# Altium schematic sheet [03] - GPS-SL871-Module-V1.1.SchDoc
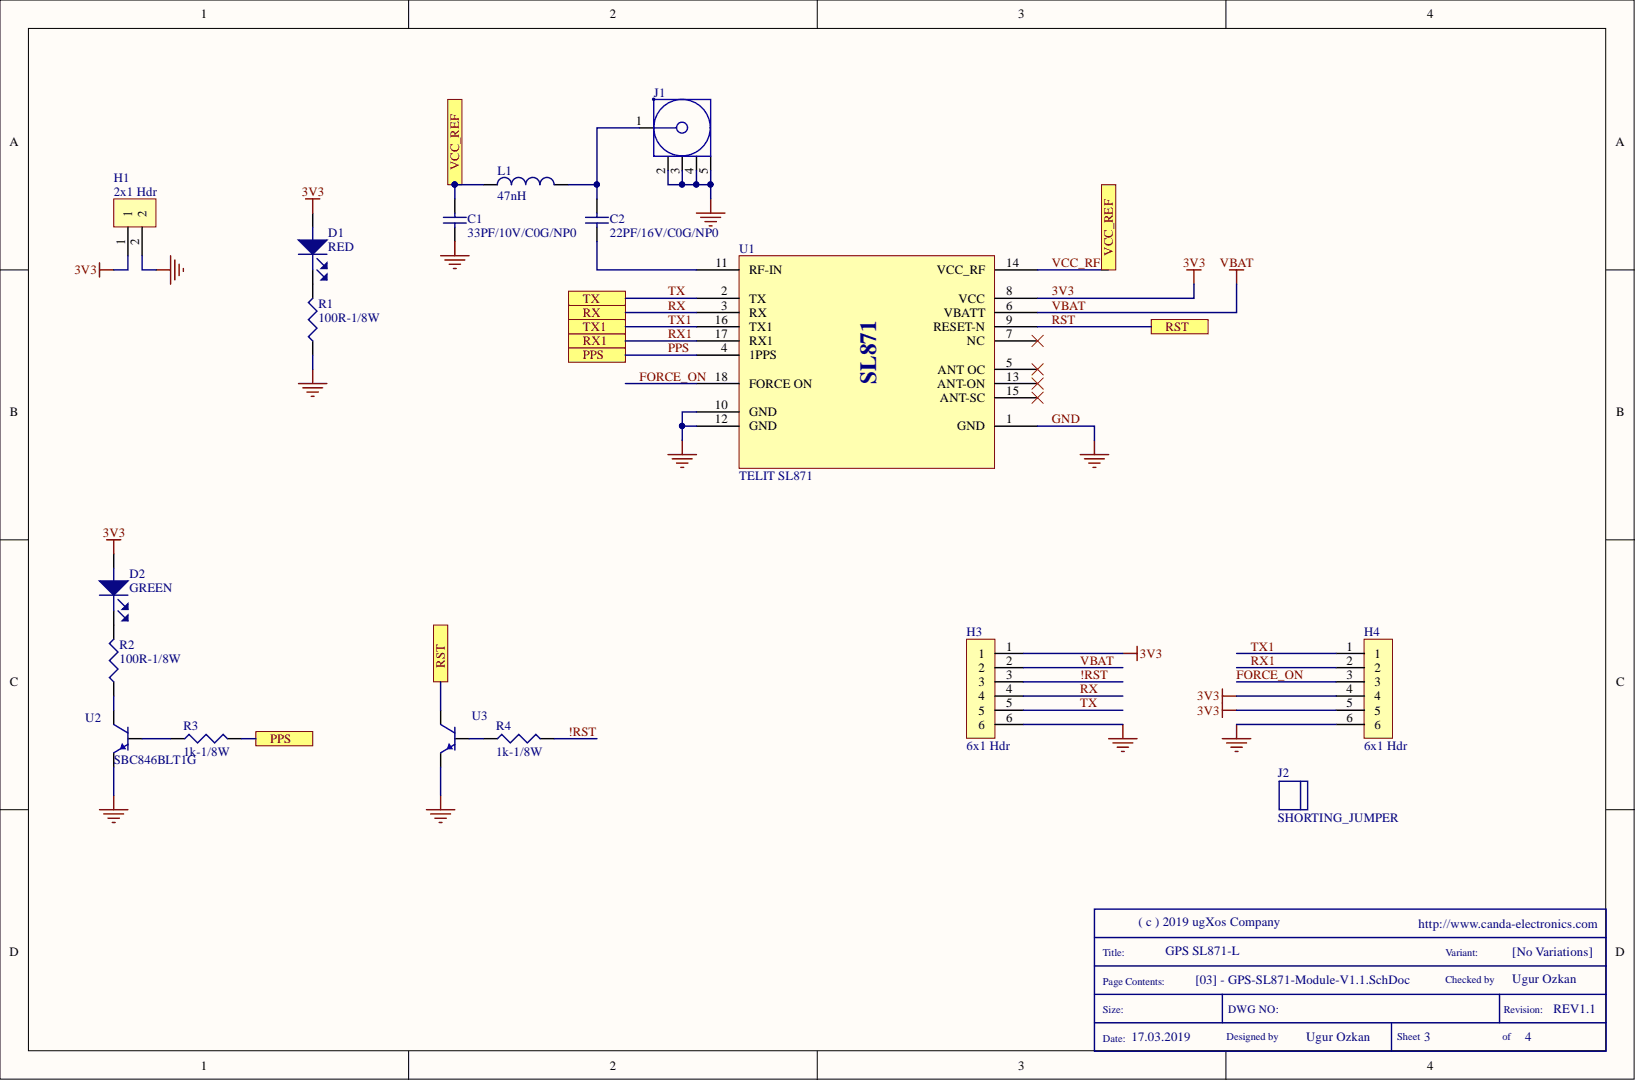
[diagram p0: [03] - GPS-SL871-Module-V1.1.SchDoc]

## Parts
C1  33PF/10V/C0G/NP0  [CAP0805]
C2  22PF/16V/C0G/NP0  [CAP0805]
D1  RED  [LED_0805]
D2  GREEN  [LED_0805]
H1  2x1 Hdr  [Hdr_Male_1x2_2.54mm]
H3  6x1 Hdr  [Hdr_Female_1x6_2.54mm]
H4  6x1 Hdr  [Hdr_Female_1x6_2.54mm]
J1  WR-SMA-THT  [WR_SMA_THT_60311002114501]  (value not drawn)
L1  47nH  [L0805]
R1  100R-1/8W  [R0805]
R2  100R-1/8W  [R0805]
R3  1k-1/8W  [R0805]
R4  1k-1/8W  [R0805]
U1  TELIT SL871  [Telit_SL871]
U2  SBC846BLT1G  [SOT-23]
U3  SBC846BLT1G  [SOT-23]

## Ports
PPS, RST, RX, RX1, TX, TX1, VCC_REF

## Nets
3V3: D1.1 D2.1 H1.1 H3.1 H4.4 H4.5 U1.8(VCC)
!RST: H3.3 R4.2
FORCE_ON: H4.3 U1.18(FORCE_ON)
GND: C1.2 H1.2 H3.6 H4.6 J1.2 J1.3 J1.4 J1.5 R1.1 U1.1(GND) U1.10(GND) U1.12(GND) U2.2 U3.2
PPS: R3.2 U1.4(1PPS) ; port PPS
RST: U1.9(RESET-N) U3.3 ; port RST
RX: H3.4 U1.3(RX) ; port RX
RX1: H4.2 U1.17(RX1) ; port RX1
TX: H3.5 U1.2(TX) ; port TX
TX1: H4.1 U1.16(TX1) ; port TX1
VBAT: H3.2 U1.6(VBATT)
VCC_RF: C1.1 L1.1 U1.14(VCC_RF) ; port VCC_REF
NetC2_1: C2.1 U1.11(RF-IN)
NetC2_2: C2.2 J1.1 L1.2
NetD1_2: D1.2 R1.2
NetD2_2: D2.2 R2.2
NetR2_1: R2.1 U2.3
NetR3_1: R3.1 U2.1
NetR4_1: R4.1 U3.1

Not connected: U1 5(ANT_OC) 7(NC) 13(ANT-ON) 15(ANT-SC)

## Library data of the parts
C1 = 885012007003: CAP CER 33PF 10V C0G/NP0 0805; Manufacturer: Wurth Electronics; Tolerance: 5%; Capacitance: 33pF; Case/Package: 0805; Case Code (Imperial): 0805; Case Code (Metric): 2012; Category: Capacitors; Component Kind: Standard; Length: 0.079inch; Manufacturer Part Number: 885012007003; Max Operating Temperature: 125°C; Min Operating Temperature: -55°C; Mount: Surface Mount; Packaging: Tape and Reel; Pricing 1: 1 = 0,10000, 10 = 0,04100, 100 = 0,03690, 500 = 0,03262 (USD); RoHS: Yes; Stock 1: 2029; Supplier 1: Digi-Key; Supplier Part Number 1: 732-7808-1-ND; Thickness: 0.028inch; Voltage Rating: 10V; Width: 0.049inch; Library Name: ugXos_Library.SchLib
C2 = 885012007012: CAP CER 22PF 16V C0G/NP0 0805; Manufacturer: Wurth Electronics; Tolerance: 5%; Capacitance: 22pF; Case/Package: 0805; Case Code (Imperial): 0805; Case Code (Metric): 2012; Category: Capacitors; Component Kind: Standard; Length: 0.079inch; Manufacturer Part Number: 885012007012; Max Operating Temperature: 125°C; Min Operating Temperature: -55°C; Mount: Surface Mount; Packaging: Tape and Reel; Pricing 1: 1 = 0,10000, 10 = 0,04300, 100 = 0,03830, 500 = 0,03390 (USD); RoHS: Yes; Stock 1: 22; Supplier 1: Digi-Key; Supplier Part Number 1: 732-7816-1-ND; Thickness: 0.028inch; Voltage Rating: 16V; Width: 0.049inch; Library Name: ugXos_Library.SchLib
D1 = 150080RS75000: LED, 0805, RED, 150MCD, 625NM; Manufacturer: Wurth Electronics; Forward Current: 30mA; Forward Voltage: 2V; Lens Style: Clear,Rectangular; Test Current: 20mA; Viewing Angle: 140°; Case/Package: 0805; Case Code (Imperial): 0805; Case Code (Metric): 2012; Category: Optoelectronics; Color: Red; Component Kind: Standard; ComponentLink1Description: http://www.farnell.com/datasheets/1911350.pdf?src-supplier=Element14; ComponentLink1URL: http://www.farnell.com/datasheets/1911350.pdf?src-supplier=Element14; ComponentLink2Description: http://www.produktinfo.conrad.com/datenblaetter/750000-774999/759435-da-01-en-CHIPLED_0805_W_KL_ROT_150080RS75000.pdf?src-supplier=Conrad; ComponentLink2URL: http://www.produktinfo.conrad.com/datenblaetter/750000-774999/759435-da-01-en-CHIPLED_0805_W_KL_ROT_150080RS75000.pdf?src-supplier=Conrad; Dominant Wavelength: 625nm; Height: 0.7mm; Length: 2mm; Luminous Intensity: 150mcd; Manufacturer Part Number: 150080RS75000; Max Operating Temperature: 85°C; Min Operating Temperature: -40°C; Mount: Surface Mount; Package Quantity: 4000; Packaging: Tape and Reel; Pricing 1: 1 = 0,17000, 50 = 0,16000, 100 = 0,13700, 500 = 0,11800 (USD); REACH SVHC: Unknown; RoHS: Yes; Stock 1: 58016; Supplier 1: Digi-Key; Supplier Part Number 1: 732-4984-1-ND; Wavelength: 625nm; Width: 1.25mm; Library Name: ugXos_Library.SchLib
D2 = 150080VS75000: LED, 0805, B GREEN, 40MCD, 570NM; Manufacturer: Wurth Electronics; Forward Current: 30mA; Forward Voltage: 2V; Lens Style: Clear,Rectangular; Test Current: 20mA; Viewing Angle: 140°; Case/Package: 0805; Case Code (Imperial): 0805; Case Code (Metric): 2012; Category: Optoelectronics; Color: Green; Component Kind: Standard; ComponentLink1Description: http://www.farnell.com/datasheets/1911352.pdf?src-supplier=Element14; ComponentLink1URL: http://www.farnell.com/datasheets/1911352.pdf?src-supplier=Element14; ComponentLink2Description: https://katalog.we-online.de/led/datasheet/150080VS75000.pdf?src-supplier=Digi-Key; ComponentLink2URL: https://katalog.we-online.de/led/datasheet/150080VS75000.pdf?src-supplier=Digi-Key; ComponentLink3Description: http://www.produktinfo.conrad.com/datenblaetter/750000-774999/759437-da-01-en-CHIPLED_0805_W_KL_H_GRUEN_150080VS7500.pdf?src-supplier=Conrad; ComponentLink3URL: http://www.produktinfo.conrad.com/datenblaetter/750000-774999/759437-da-01-en-CHIPLED_0805_W_KL_H_GRUEN_150080VS7500.pdf?src-supplier=Conrad; Dominant Wavelength: 570nm; Height: 0.7mm; Length: 2mm; Luminous Intensity: 40mcd; Manufacturer Part Number: 150080VS75000; Max Operating Temperature: 85°C; Min Operating Temperature: -40°C; Mount: Surface Mount; Package Quantity: 4000; Packaging: Tape and Reel; Pricing 1: 1 = 0,17000, 50 = 0,16000, 100 = 0,13700, 500 = 0,11800 (USD); REACH SVHC: Unknown; RoHS: Yes; Stock 1: 80308; Supplier 1: Digi-Key; Supplier Part Number 1: 732-4986-1-ND; Wavelength: 570nm; Width: 1.25mm; Library Name: ugXos_Library.SchLib
H1 = 61300211121: Connector, Vertical, Through Hole, Header, 2, 2.54 Mm; Manufacturer: Wurth Electronics; Connector Type: Male Pin; Current Rating: 3A; Gender: Male; Number of Contacts: 2; Pitch: 0.1inch; Voltage Rating: 250V; Category: Connectors; Color: Black; Component Kind: Standard; ComponentLink1Description: http://www.mouser.com/ds/2/445/6130xx11121-538006.pdf?src-supplier=Mouser; ComponentLink1URL: http://www.mouser.com/ds/2/445/6130xx11121-538006.pdf?src-supplier=Mouser; Contact Gender: Male; Contact Material: Copper,Copper Alloy; Contact Mating Length: 0.236inch; Contact Plating: Gold; Housing Material: PBT; Lead Free: Lead Free; Manufacturer Part Number: 61300211121; Max Operating Temperature: 125°C; Min Operating Temperature: -40°C; Mount: Through Hole; Number of Positions: 2; Number of Rows: 1; Packaging: Bulk; Pricing 1: 1 = 0,13000, 10 = 0,10600, 25 = 0,08800, 50 = 0,07400, 100 = 0,06700, 1000 = 0,06100 (USD); REACH SVHC: Unknown; RoHS: Yes; Stock 1: 132528; Supplier 1: Digi-Key; Supplier Part Number 1: 732-5315-ND; Termination: Through Hole; Library Name: ugXos_Library.SchLib
H3 H4 = 61300611121: Connector, Vertical, Through Hole, Header 6, 2.54 Mm; Manufacturer: Wurth Electronics; Connector Type: Male Pin; Current Rating: 3A; Gender: Male; Number of Contacts: 6; Pitch: 0.1inch; Voltage Rating: 250V; Category: Connectors; Color: Black; Component Kind: Standard; Contact Gender: Male; Contact Material: Copper,Copper Alloy; Contact Mating Length: 0.236inch; Contact Plating: Gold; Housing Material: PBT; Lead Free: Lead Free; Manufacturer Part Number: 61300611121; Max Operating Temperature: 125°C; Min Operating Temperature: -40°C; Mount: Through Hole; Number of Positions: 6; Number of Rows: 1; Packaging: Bulk; Pricing 1: 1 = 0,33000, 10 = 0,27900, 25 = 0,23200, 50 = 0,19400, 100 = 0,17600, 1000 = 0,16000 (USD); REACH SVHC: Unknown; RoHS: Yes; Stock 1: 13513; Supplier 1: Digi-Key; Supplier Part Number 1: 732-5319-ND; Termination: Through Hole; Library Name: ugXos_Library.SchLib
J1 = 60311002114501: WR-SMA SMA PCB THT Jack Right Angle; Manufacturer: Wurth Electronics; Frequency Range: DC~18 GHz; Impedance: 50 Ohm; Category: Connectors; Component Kind: Standard; ComponentLink1Description: Manufacturer; ComponentLink1URL: http://www.we-online.de; ComponentLink2Description: Datasheet; ComponentLink2URL: https://katalog.we-online.de/em/datasheet/60311002114501.pdf; Connector Type: SMA; Gender: Jack; Manufacturer Part Number: 60311002114501; Mounting Technology: THT; Operating Temperature: -65 to 165 degC; Orientation Type: Right Angle; Packaging: Tray; Part Number: 60311002114501; Published Date: August 2018; Library Name: ugXos_Library.SchLib; Publisher: Wurth Elektronik
L1 = IND_0805: IND 0805; Component Kind: Standard; Library Name: ugXos_Library.SchLib
R1 R2 = ERA6AEB101V: RES SMD 100 OHM 0.1% 1/8W 0805; Manufacturer: Panasonic; Max Power Dissipation: 125mW; Resistance: 100R; Temperature Coefficient: 25ppm/°C; Voltage Rating: 100V; Case/Package: 0805; Case Code (Imperial): 0805; Case Code (Metric): 2012; Category: Resistor; Component Kind: Standard; ComponentLink1Description: https://www.alliedelec.com/m/d/b6b0537e86f421aa7f5975bb1d8f429a.pdf?src-supplier=Allied+Electronics; ComponentLink1URL: https://www.alliedelec.com/m/d/b6b0537e86f421aa7f5975bb1d8f429a.pdf?src-supplier=Allied+Electronics; ComponentLink2Description: http://www.farnell.com/datasheets/485442.pdf?src-supplier=Farnell; ComponentLink2URL: http://www.farnell.com/datasheets/485442.pdf?src-supplier=Farnell; ComponentLink3Description: http://www.farnell.com/datasheets/485442.pdf?src-supplier=Element14; ComponentLink3URL: http://www.farnell.com/datasheets/485442.pdf?src-supplier=Element14; ComponentLink4Description: http://industrial.panasonic.com/www-data/pdf/AOA0000/AOA0000CE26.pdf?src-supplier=Avnet+Europe; ComponentLink4URL: http://industrial.panasonic.com/www-data/pdf/AOA0000/AOA0000CE26.pdf?src-supplier=Avnet+Europe; ComponentLink5Description: http://www.mouser.com/ds/2/315/AOA0000CE26-32660.pdf?src-supplier=Mouser; ComponentLink5URL: http://www.mouser.com/ds/2/315/AOA0000CE26-32660.pdf?src-supplier=Mouser; ComponentLink6Description: https://www.distrelec.biz/Web/Downloads/_t/ds/ERA_eng_tds.pdf?mime=application%2Fpdf&src-supplier=Distrelec; ComponentLink6URL: https://www.distrelec.biz/Web/Downloads/_t/ds/ERA_eng_tds.pdf?mime=application%2Fpdf&src-supplier=Distrelec; ComponentLink7Description: http://industrial.panasonic.com/www-data/pdf/AOA0000/AOA0000CE26.pdf?src-supplier=Future+Electronics; ComponentLink7URL: http://industrial.panasonic.com/www-data/pdf/AOA0000/AOA0000CE26.pdf?src-supplier=Future+Electronics; ComponentLink8Description: http://industrial.panasonic.com/www-data/pdf/AOA0000/AOA0000CE26.pdf?src-supplier=Avnet; ComponentLink8URL: http://industrial.panasonic.com/www-data/pdf/AOA0000/AOA0000CE26.pdf?src-supplier=Avnet; ComponentLink9Description: http://uk.rs-online.com/webdocs/0bee/0900766b80beefcc.pdf?src-supplier=RSComponents; ComponentLink9URL: http://uk.rs-online.com/webdocs/0bee/0900766b80beefcc.pdf?src-supplier=RSComponents; ComponentLink10Description: https://produktinfo.conrad.com/datenblaetter/450000-474999/452698-da-01-en-WIDERSTAND_0805_ERA.pdf?src-supplier=Conrad; ComponentLink10URL: https://produktinfo.conrad.com/datenblaetter/450000-474999/452698-da-01-en-WIDERSTAND_0805_ERA.pdf?src-supplier=Conrad; Composition: Metal Film,Thin Film; Contact Plating: Tin; Depth: 1.25mm; Features: Automotive AEC-Q200; Height: 0.5mm; Lead Free: Lead Free; Length: 2mm; Manufacturer Part Number: ERA6AEB101V; Max Operating Temperature: 155°C; Military Standard: Not; Min Operating Temperature: -55°C; Mount: Surface Mount; Number of Pins: 2; Number of Terminations: 2; Package Quantity: 1; Packaging: Tape and Reel; Power Rating: 125mW; Pricing 1: 1 = 0,36000, 10 = 0,30200, 100 = 0,11820, 1000 = 0,04948, 2500 = 0,04536 (USD); Radiation Hardening: No; REACH SVHC: No SVHC; RoHS: Yes; Stock 1: 384718; Supplier 1: Digi-Key; Supplier Part Number 1: P100DACT-ND; Termination: SMD/SMT; Tolerance: 0.1%; Voltage Rating (DC): 100V; Width: 1.25mm; Library Name: ugXos_Library.SchLib
R3 R4 = ERA-6AED102V: Res Thin Film 0805 1K Ohm 0.5% 0.125W(1/8W) ±25ppm/C Molded SMD Automotive Punched T/R; Manufacturer: Panasonic; Power Rating: 125mW; Resistance: 1kR; Temperature Coefficient: 25ppm/°C; Voltage Rating: 100V; Case/Package: 0805; Case Code (Imperial): 0805; Case Code (Metric): 2012; Category: Resistor; Component Kind: Standard; ComponentLink1Description: http://industrial.panasonic.com/www-data/pdf/AOA0000/AOA0000CE26.pdf?src-supplier=Avnet; ComponentLink1URL: http://industrial.panasonic.com/www-data/pdf/AOA0000/AOA0000CE26.pdf?src-supplier=Avnet; Composition: Thin Film; Contact Plating: Tin; Depth: 1.25mm; Height: 0.5mm; Lead Free: Lead Free; Length: 2mm; Manufacturer Part Number: ERA-6AED102V; Max Operating Temperature: 155°C; Military Standard: Not; Min Operating Temperature: -55°C; Mount: Surface Mount; Package Quantity: 5000; Packaging: Tape and Reel; Pricing 1: 1 = 0,12000, 10 = 0,10900, 25 = 0,07520, 50 = 0,05860, 100 = 0,04180, 250 = 0,03260, 500 = 0,02592, 1000 = 0,01839 (USD); RoHS: Yes; Stock 1: 20040; Supplier 1: Digi-Key; Supplier Part Number 1: P123793CT-ND; Tolerance: 0.5%; Width: 1.25mm; Library Name: ugXos_Library.SchLib
U1 = TELIT SL871: Telit GNSS MODULE; Category: GSM/GPS; Component Kind: Standard; Manufacturer: Telit; Manufacturer Part Number: TELIT SL871; Library Name: ugXos_Library.SchLib
U2 U3 = SBC846BLT1G: BC846 NPN 65V 0.1A SOT-23; Manufacturer: ON Semiconductor; Current - Collector Cutoff (Max): 15nA (ICBO); DC Current Gain (hFE) (Min) @ Ic, Vce: 200 @ 2mA, 5V; Frequency - Transition: 100MHz; Vce Saturation (Max) @ Ib, Ic: 600mV @ 5mA, 100mA; Category: Discrete Semiconductor Products; Component Kind: Standard; ComponentLink1Description: http://www.onsemi.com/pub/Collateral/BC846ALT1-D.PDF; ComponentLink1URL: http://www.onsemi.com/pub/Collateral/BC846ALT1-D.PDF; Manufacturer Part Number: SBC846BLT1G; Mounting Type: Surface Mount; Operating Temperature: -55°C ~ 150°C (TJ); Package / Case: TO-236-3, SC-59, SOT-23-3; Packaging: Cut Tape (CT); Part Status: Active; Pricing 1: 1=0.31, 10=0.256, 100=0.1356, 500=0.08922, 1000=0.06067 (USD); RoHS: RoHS Compliant; Stock 1: 23802; Supplier 1: Digi-Key; Supplier Device Package: SOT-23-3 (TO-236); Supplier Part Number 1: SBC846BLT1GOSCT-ND; Transistor Type: NPN; Library Name: ugXos_Library.SchLib
